# Altium schematic sheet PSOC62_Adapter_V1.2.SchDoc
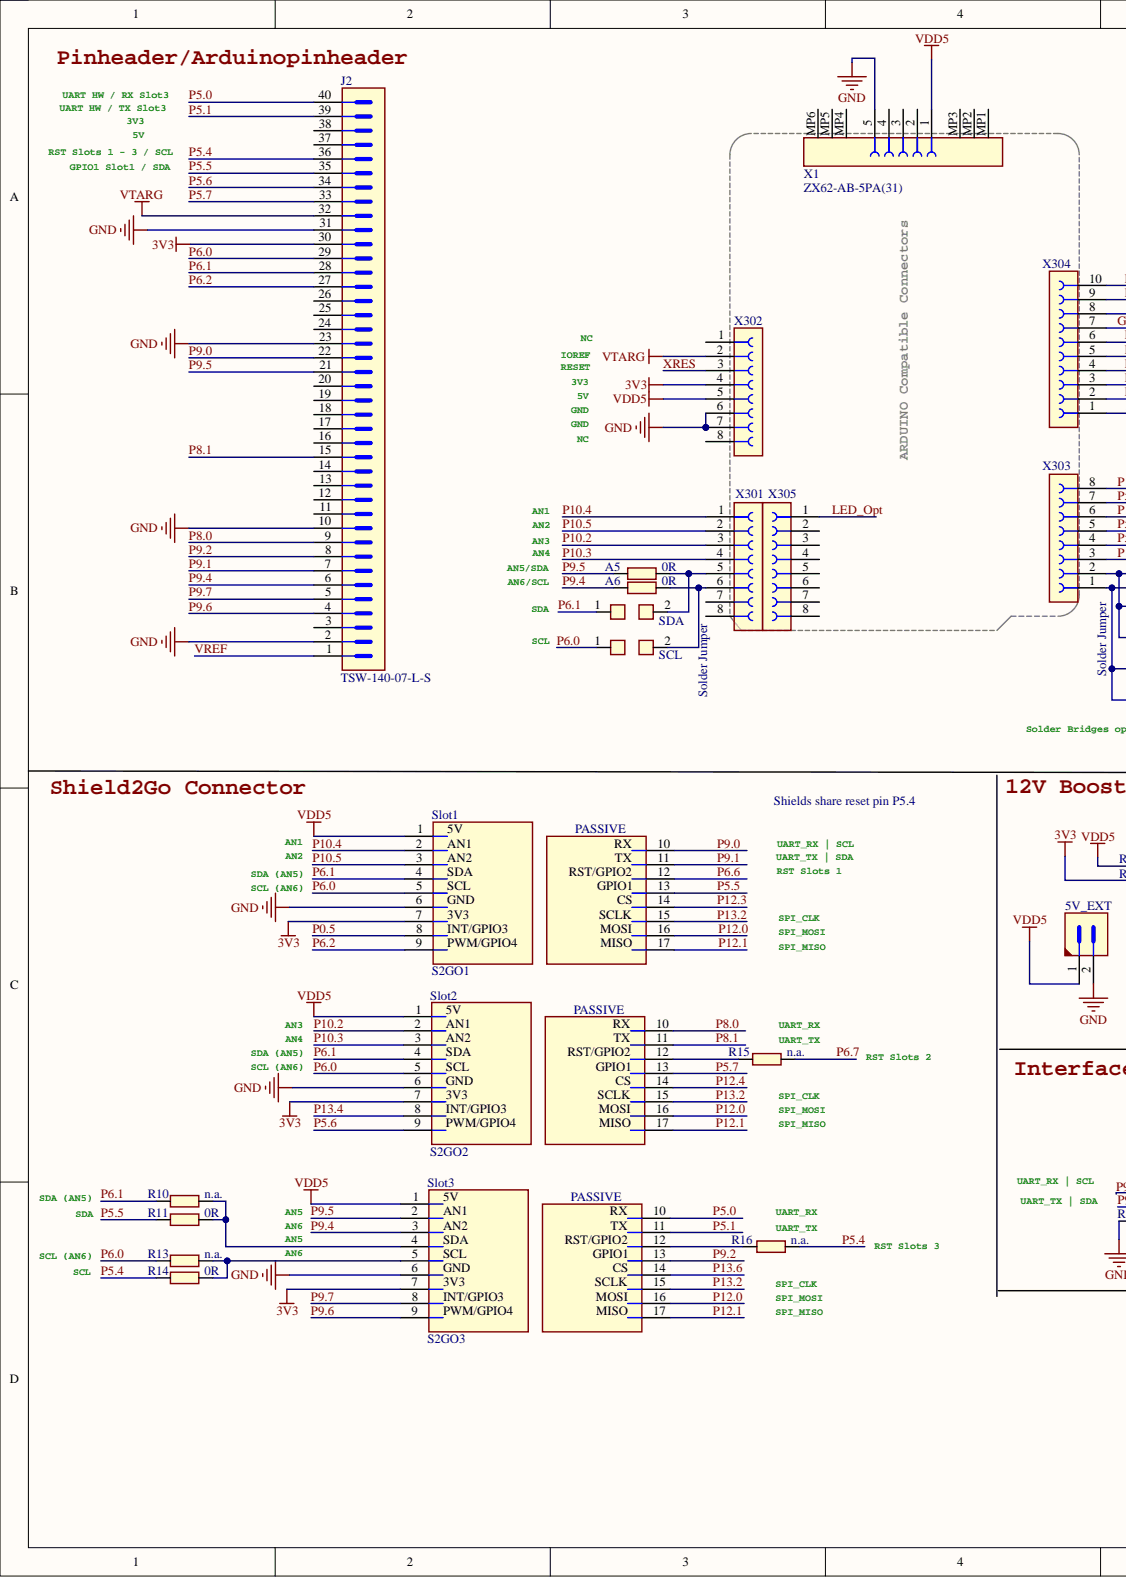
[diagram p0: PSOC62_Adapter_V1.2.SchDoc, left]
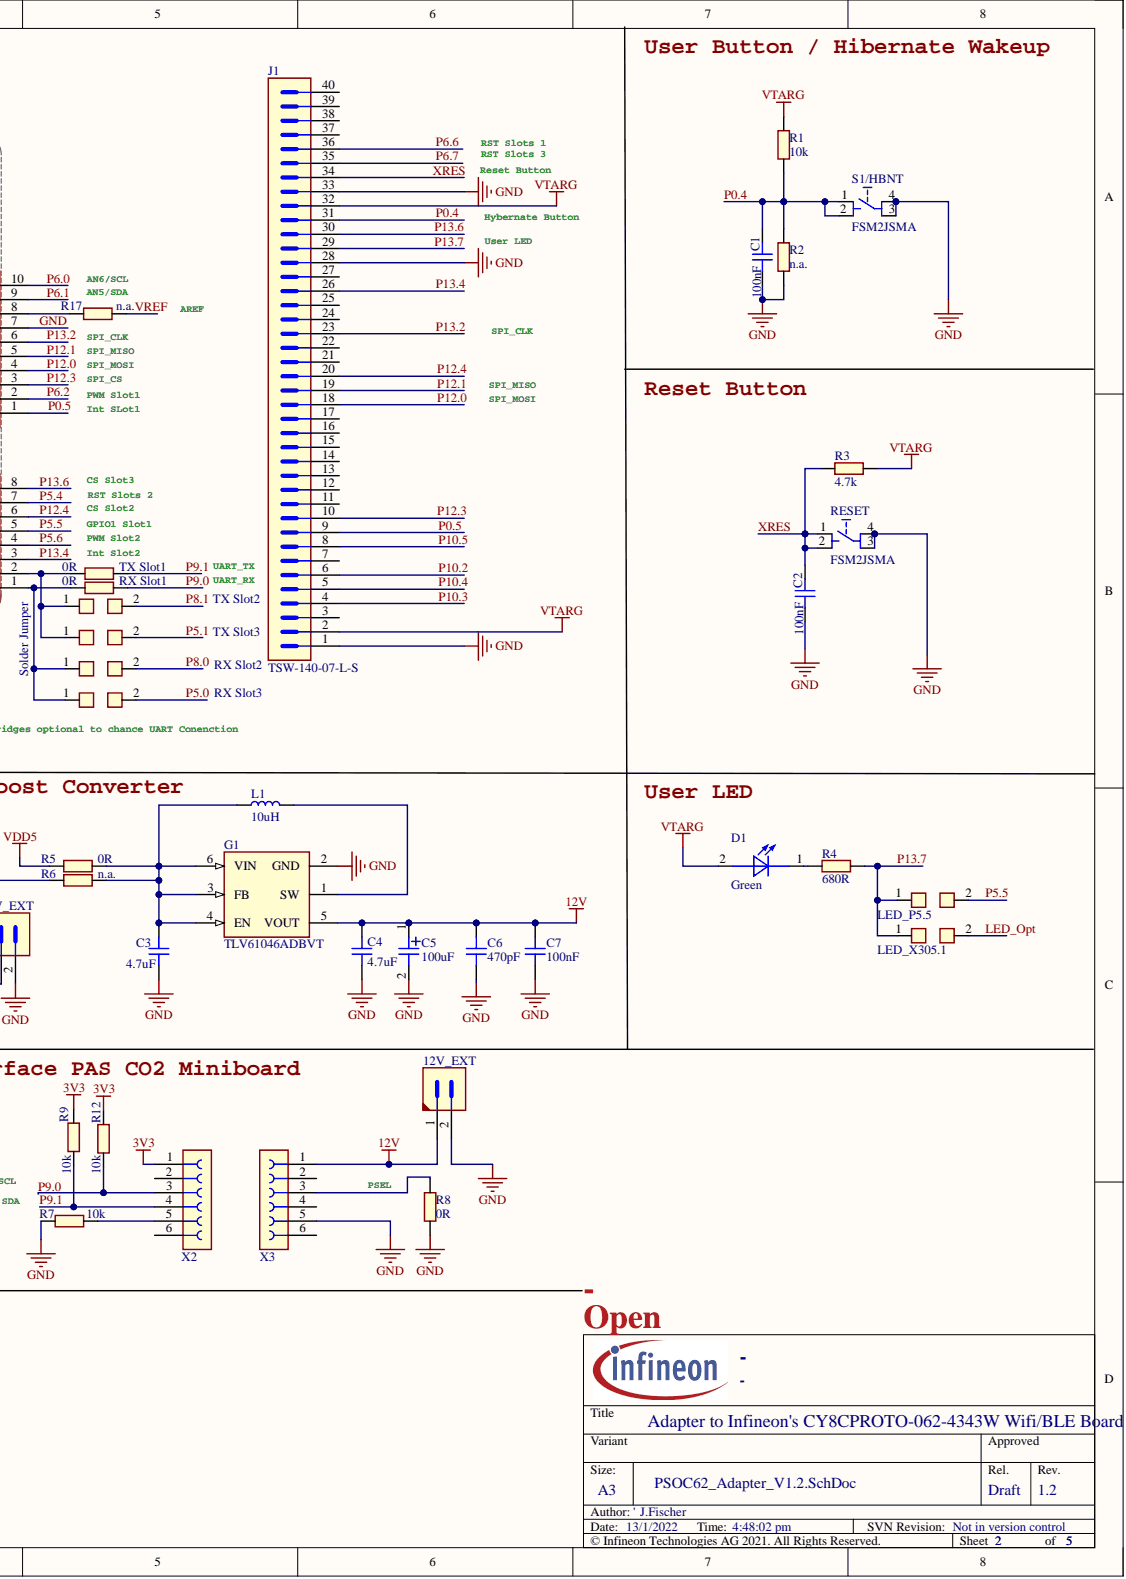
[diagram p1: PSOC62_Adapter_V1.2.SchDoc, right]

## Parts
5V_EXT  TSW-102-07-L-S  [CON-M-THT-TSW-102-07-L-S]  (value not drawn)
12V_EXT  TSW-102-07-L-S  [CON-M-THT-TSW-102-07-L-S]  (value not drawn)
A5  0R  [RESC3225X60N]
A6  0R  [RESC3225X60N]
C1  100nF  [CAPC2013X88N]
C2  100nF  [CAPC2013X88N]
C3  4.7uF  [CAPC1608X90N]
C4  4.7uF  [CAPC1608X90N]
C5  100uF  [CAPAE660X800N-1]
C6  470pF  [CAPC1608X90N]
C7  100nF  [CAPC1608X90N]
D1  Green  [LED-SMD-LG L29K-XXXX-24]
G1  TLV61046ADBVT  [SOT95P280X145-6N-6]
J1  TSW-140-07-L-S  [CON-M-THT-TSW-140-07-L-S]
J2  TSW-140-07-L-S  [CON-M-THT-TSW-140-07-L-S]
L1  10uH  [IND-SMD-VLCF5020]
LED_P5.5  Solder Jumper  [SOLDER_BRIDGE_0.7X1.299PAD]
LED_X305.1  Solder Jumper  [SOLDER_BRIDGE_0.7X1.299PAD]
R1  10k  [RESC3225X60N]
R2  n.a.  [RESC3225X60N]
R3  4.7k  [RESC3225X60N]
R4  680R  [RESC2113X50N]
R5  0R  [RESC3225X60N]
R6  n.a.  [RESC3225X60N]
R7  10k  [RESC3225X60N]
R8  0R  [RESC3225X60N]
R9  10k  [RESC3225X60N]
R10  n.a.  value 0R  Tolerance Neg: 0R  [RESC3225X60N]
R11  0R  [RESC3225X60N]
R12  10k  [RESC3225X60N]
R13  n.a.  value 0R  Tolerance Neg: 0R  [RESC3225X60N]
R14  0R  [RESC3225X60N]
R15  n.a.  [RESC3225X60N]
R16  n.a.  [RESC3225X60N]
R17  n.a.  [RESC2013X60N]
RESET  FSM2JSMA  [FSM2JSMA]
RX_Slot1  0R  [RESC3225X60N]
RX_Slot2  Solder Jumper  [SOLDER_BRIDGE_0.7X1.299PAD]
RX_Slot3  Solder Jumper  [SOLDER_BRIDGE_0.7X1.299PAD]
S1/HBNT  FSM2JSMA  [FSM2JSMA]
SCL  Solder Jumper  [SOLDER_BRIDGE_0.7X1.299PAD]
SDA  Solder Jumper  [SOLDER_BRIDGE_0.7X1.299PAD]
Slot1  S2GO1  [Shield2Go_S2G_1P]  (no BOM)
Slot2  S2GO2  [Shield2Go_S2G_1P]  (no BOM)
Slot3  S2GO3  [Shield2Go_S2G_1P]  (no BOM)
TX_Slot1  0R  [RESC3225X60N]
TX_Slot2  Solder Jumper  [SOLDER_BRIDGE_0.7X1.299PAD]
TX_Slot3  Solder Jumper  [SOLDER_BRIDGE_0.7X1.299PAD]
X1  ZX62-AB-5PA(31)  [CON-USB-SMD-ZX62-AB-5PA(31)]
X2  Socket 6  [CON-F-THT-929850-01-06-RA]  (value not drawn)
X3  Socket 6  [CON-F-THT-929850-01-06-RA]  (value not drawn)
X301  Socket 8  [CON-F-THT-PPTC081LFBN-RC]  (value not drawn)
X302  Socket 8  [CON-F-THT-PPTC081LFBN-RC]  (value not drawn)
X303  Socket 8  [CON-F-THT-PPTC081LFBN-RC]  (value not drawn)
X304  SSQ-110-03-F-S  [CON-F-THT-SSQ-110-03-F-S]  (value not drawn)
X305  Socket 8  [CON-F-THT-PPTC081LFBN-RC]  (value not drawn)

## Nets
3V3: J2.30 R6.2 R9.1 R12.1 Slot1.7 Slot2.7 Slot3.7 X2.1 X302.4
12V: 12V_EXT.1 C4.2 C5.1 C6.2 C7.2 G1.5(VOUT) X3.1
VDD5: 5V_EXT.1 R5.2 Slot1.1 Slot2.1 Slot3.1 X1.1 X302.5
VTARG: D1.2 J1.2 J1.32 J2.32 R1.2 R3.2 X302.2
GND: 5V_EXT.2 12V_EXT.2 C1.2 C2.2 C3.1 C4.1 C5.2 C6.1 C7.1 G1.2(GND) J1.1 J1.28 J1.33 J2.2 J2.10 J2.23 J2.31 R2.1 R7.2 R8.2 RESET.3 RESET.4 S1/HBNT.3 S1/HBNT.4 Slot1.6 Slot2.6 Slot3.6 X1.5 X3.5 X302.6 X302.7 X304.7
LED_Opt: LED_X305.1.2 X305.1
P0.4: C1.1 J1.31 R1.1 R2.2 S1/HBNT.1 S1/HBNT.2
P0.5: J1.9 Slot1.8 X304.1
P5.0: J2.40 RX_Slot3.2 Slot3.10
P5.1: J2.39 Slot3.11 TX_Slot3.2
P5.4: J2.36 R14.2 R16.1 X303.7
P5.5: J2.35 LED_P5.5.2 R11.2 Slot1.13 X303.5
P5.6: J2.34 Slot2.9 X303.4
P5.7: J2.33 Slot2.13
P6.0: J2.29 R13.2 SCL.1 Slot1.5 Slot2.5 X304.10
P6.1: J2.28 R10.2 SDA.1 Slot1.4 Slot2.4 X304.9
P6.2: J2.27 Slot1.9 X304.2
P6.6: J1.36 Slot1.12
P6.7: J1.35 R15.1
P8.0: J2.9 RX_Slot2.2 Slot2.10
P8.1: J2.15 Slot2.11 TX_Slot2.2
P9.0: J2.22 R12.2 RX_Slot1.1 Slot1.10 X2.3
P9.1: J2.7 R9.2 Slot1.11 TX_Slot1.1 X2.4
P9.2: J2.8 Slot3.13
P9.4: A6.2 J2.6 Slot3.3
P9.5: A5.2 J2.21 Slot3.2
P9.6: J2.4 Slot3.9
P9.7: J2.5 Slot3.8
P10.2: J1.6 Slot2.2 X301.3
P10.3: J1.4 Slot2.3 X301.4
P10.4: J1.5 Slot1.2 X301.1
P10.5: J1.8 Slot1.3 X301.2
P12.0: J1.18 Slot1.16 Slot2.16 Slot3.16 X304.4
P12.1: J1.19 Slot1.17 Slot2.17 Slot3.17 X304.5
P12.3: J1.10 Slot1.14 X304.3
P12.4: J1.20 Slot2.14 X303.6
P13.2: J1.23 Slot1.15 Slot2.15 Slot3.15 X304.6
P13.4: J1.26 Slot2.8 X303.3
P13.6: J1.30 Slot3.14 X303.8
P13.7: J1.29 LED_P5.5.1 LED_X305.1.1 R4.2
VREF: J2.1 R17.2
XRES: C2.1 J1.34 R3.1 RESET.1 RESET.2 X302.3
NetA5_1: A5.1 SDA.2 X301.5
NetA6_1: A6.1 SCL.2 X301.6
NetC3_2: C3.2 G1.3(FB) G1.4(EN) G1.6(VIN) L1.1 R5.1 R6.1
NetD1_1: D1.1 R4.1
NetG1_1: G1.1(SW) L1.2
NetR7_1: R7.1 X2.5
NetR8_1: R8.1 X3.3
NetR10_1: R10.1 R11.1 Slot3.4
NetR13_1: R13.1 R14.1 Slot3.5
NetR15_2: R15.2 Slot2.12
NetR16_2: R16.2 Slot3.12
NetR17_1: R17.1 X304.8
NetRX Slot1_2: RX_Slot1.2 RX_Slot2.1 RX_Slot3.1 X303.1
NetTX Slot1_2: TX_Slot1.2 TX_Slot2.1 TX_Slot3.1 X303.2

Not connected: J1 3 7 11 12 13 14 15 16 17 21 22 24 25 27 37 38 39 40; J2 3 11 12 13 14 16 17 18 19 20 24 25 26 37 38; X1 2 3 4 MP1 MP2 MP3 MP4 MP5 MP6; X2 2 6; X3 2 4 6; X301 7 8; X302 1 8; X305 2 3 4 5 6 7 8

## Library data of the parts
5V_EXT 12V_EXT = 382904: Through hole .025 SQ Post Header, 2.54mm pitch, 2 pin, vertical, single row; Legacy PartNumber: CON01500574; Manufacturer: Samtec; Alternat View: Yes; Automotive Qualified: No; Combined Parameters: Board-to-Board Header (male);2x1;Mounting:THT;Orient:0Â° (vertical);Shielded:No; Database Table Name: tParts_all#csv; Legacy DeviceID: SAM_TSW-102-07-L-S; PLMStatus: Active; QuickPick: 0Â° (vertical) / Board-to-Board; Style: Strip; Version Number: V01; Voltage DC (max): na; Article Number: 382904; Component Kind: Standard; ComponentLink1Description: Vendor WebSite; ComponentLink1URL: http://www.samtec.com/; ComponentLink2Description: Datasheet; ComponentLink2URL: http://webnetprod.muc.infineon.com/PCBPortal_Prod/WorkFlows/AltiumComponent/StandardDocumentation?Versionid=382905; Library Name: PCBLibraryData.SVNDblib; Manufacturer Order Number: TSW-102-07-L-S; Package Description: Header,vertical,2.54mm pitch;0.64mm lead width,2 pins,1 row,2 pins per row,5.08mm L X 2.54mm W X 8.636mm H body; Part Type: Connector; ROHS Compliant: Y; Second Source allowed: Yes; Supplier 1: Farnell; Supplier 2: DigiKey; Supplier 3: Mouser; Supplier Part Number 1: 2667448; Supplier Part Number 2: SAM1031-02-ND; Temperature max: 125; Temperature min: -55; Temperature Range: Military; Type: Board-to-Board; Value: TSW-102-07-L-S
A5 A6 R5 R10 R11 R13 R14 RX_Slot1 TX_Slot1 = 355897: Standard Thick Film Chip Resistor; Manufacturer: Vishay; Manufacturer Order Number: CRCW12100000Z0; Multiple Parts: No; Alternate View: Yes; Automotive Qualified: AEC Q200; Combined Parameters: TCR:-;Tol.:-0R/+0R;Vdc:200V;Idc:5A;P:-; Database Table Name: tParts_all#csv; Legacy DeviceID: RES01803200; PLMStatus: Active; QuickPick: 0R / 0R / 1210; Style: Single; Symbol Type: Resistor; Single; Standard; 2 Pins; Version Number: V01; Voltage DC (max): 200V; Article Number: 355897; Component Kind: Standard; ComponentLink1Description: Vendor WebSite; ComponentLink1URL: http://www.vishay.com; ComponentLink2Description: Datasheet; ComponentLink2URL: http://webnetprod.muc.infineon.com/PCBPortal_Prod/WorkFlows/AltiumComponent/StandardDocumentation?Versionid=355898; Legacy PartNumber: RES01803200; Library Name: PCBLibraryData.SVNDblib; PackageReference: 1210; Part Type: Resistor; ROHS Compliant: Y; Second Source allowed: Yes; Supplier 1: Farnell; Supplier 2: DigiKey; Supplier 3: Mouser; Technology: Thick Film; Temperature max: 155; Temperature min: -55; Temperature Range: Military; Tolerance Pos: 0R; Type: Standard
  A5 A6 R5 R11 R14 RX_Slot1 TX_Slot1: Tolerance Neg: 0R; Value: 0R
C1 C2 = 251082: Surface Mount Multilayer Ceramic Chip Capacitor; Manufacturer: Kemet; Manufacturer Order Number: C0805C104J5RAC; Multiple Parts: No; Alternate View: Yes; Combined Parameters: TC:X7R (EIA);Tol.:-5%/+5%;Vdc:50V; Database Table Name: tParts_all#csv; Legacy DeviceID: CAP00004118; Package Imperial: 0805; Package Metric: 2012; PLMStatus: Active; QuickPick: 100nF / 50V / 5% / X7R (EIA) / 0805; Style: Single; Symbol Type: Capacitor; Single; Standard; 2 Pins; Tolerance Neg: 5%; Type: Standard; Version Number: V01; Voltage DC (max): 50V; Article Number: 251082; Automotive Qualified: Yes; Component Kind: Standard; ComponentLink1Description: Vendor WebSite; ComponentLink1URL: http://www.kemet.com; ComponentLink2Description: Datasheet; ComponentLink2URL: http://webnetprod.muc.infineon.com/PCBPortal_Prod/WorkFlows/AltiumComponent/StandardDocumentation?Versionid=251083; Legacy PartNumber: CAP00004118; Library Name: PCBLibraryData.SVNDblib; Package Description: Capacitor,non-polarized,Chip;2.00mm L X 1.25mm W X 0.88mm H; PackageReference: 0805; Part Type: Capacitor; ROHS Compliant: Y; Second Source allowed: Yes; Supplier 1: Farnell; Supplier 2: DigiKey; Supplier 3: Mouser; Supplier Part Number 1: 1414663; Supplier Part Number 3: 80-C0805C104J5RAC; Technology: Ceramic; Temperature max: 125; Temperature min: -55; Temperature Range: Military; Tolerance Pos: 5%; Value: 100nF
C3 C4 = 272300: CAP / CERA / 4.7uF / 25V / 10% / X5R (EIA) / -55Â°C to 85Â°C / 0603(1608) / SMD / -; Manufacturer: MuRata; Manufacturer Order Number: GRM188R61E475KE15; Alternate View: Yes; Automotive Qualified: No; Combined Parameters: TC:X5R (EIA);Tol.:-10%/+10%;Vdc:25V; Database Table Name: tParts_all#csv; Legacy DeviceID: CAP01003467; Package Imperial: 0603; Package Metric: 1608; PLMStatus: Active; QuickPick: 4.7uF / 25V / 10% / X5R (EIA) / CAPC1608X90N; Style: Single; Symbol Type: Capacitor; Single; Standard; 2 Pins; Type: Standard; Version Number: V01; Application: Standard; Article Number: 272300; Component Kind: Standard; ComponentLink1Description: Vendor WebSite; ComponentLink1URL: http://www.murata.com/; ComponentLink2Description: Datasheet; ComponentLink2URL: http://webnetprod.muc.infineon.com/PCBPortal_Prod/WorkFlows/AltiumComponent/StandardDocumentation?Versionid=272301; Legacy PartNumber: CAP01003467; Library Name: PCBLibraryData.SVNDblib; Multiple Parts: No; Package Description: Capacitor,non-polarized,Chip;1.60mm L X 0.80mm W X 0.90mm H; PackageReference: CAPC1608X90N; Part Type: Capacitor; ROHS Compliant: Y; Second Source allowed: Yes; Supplier 1: Farnell; Supplier 2: DigiKey; Supplier 3: Mouser; Technology: Ceramic; Temperature max: 85; Temperature min: -55; Temperature Range: Military; Tolerance Neg: 10%; Tolerance Pos: 10%; Value: 4.7uF; Voltage DC (max): 25V
C5 = 309470: CAP / ELCO / 100uF / 50V / 20% / Aluminiumelectrolytic / -55Â°C to 105Â°C / 6.60mm L X 6.60mm W X 8.00mm H / SMD / -; Manufacturer: Panasonic; Manufacturer Order Number: EEEFTH101XAP; Multible Parts: No; Alternate View: Yes; Automotive Qualified: AEC Q200; Combined Parameters: TC:Aluminiumelectrolytic;Tol.:-20%/+20%;Vdc:50V; Database Table Name: tParts_all#csv; Legacy DeviceID: CAP01010767; PLMStatus: Active; QuickPick: 100uF / 50V / 20% / Aluminiumelectrolytic / SMD; Style: Single; Symbol Type: Capacitor; Single; Polarized; 2 Pins; Pin 1 Positive; Type: Polarized; Version Number: V01; Article Number: 309470; Component Kind: Standard; ComponentLink1Description: Vendor WebSite; ComponentLink1URL: http://panasonic.net/sanyo/index.html; ComponentLink2Description: Datasheet; ComponentLink2URL: http://webnetprod.muc.infineon.com/PCBPortal_Prod/WorkFlows/AltiumComponent/StandardDocumentation?Versionid=309471; Legacy PartNumber: CAP01010767; Library Name: PCBLibraryData.SVNDblib; Package Description: Capacitor,Aluminum Electrolytic;6.60mm L X 6.60mm W X 8.00mm H; PackageReference: SMD; Part Type: Capacitor; ROHS Compliant: Y; Second Source allowed: Yes; Supplier 1: Farnell; Supplier 2: DigiKey; Supplier 3: Mouser; Supplier Part Number 1: 2408683; Supplier Part Number 2: PCE5024TR-ND; Supplier Part Number 3: 667-EEE-FTH101XAP; Technology: Electrolytic; Temperature max: 105; Temperature min: -55; Temperature Range: Military; Tolerance Neg: 20%; Tolerance Pos: 20%; Value: 100uF; Voltage DC (max): 50V
C6 = 297691: CAP / CERA / 470pF / 50V / 20% / X8L (EIA) / -55Â°C to 150Â°C / 0603(1608) / SMD / -; Manufacturer: MuRata; Manufacturer Order Number: GCM188L81H471MA03D; Multiple Parts: No; Alternate View: Yes; Automotive Qualified: AEC Q200; Combined Parameters: TC:X8L (EIA);Tol.:-20%/+20%;Vdc:50V; Database Table Name: tParts_all#csv; Package Imperial: 0603; Package Metric: 1608; PLMStatus: Not Recommended For New Designs; QuickPick: 470pF / 50V / 20% / X8L (EIA) / CAPC1608X90N; Style: Single; Symbol Type: Capacitor; Single; Standard; 2 Pins; Type: Standard; Version Number: V01; Application: Standard; Article Number: 297691; Component Kind: Standard; ComponentLink1Description: Vendor WebSite; ComponentLink1URL: http://www.murata.com/; ComponentLink2Description: Datasheet; ComponentLink2URL: http://webnetprod.muc.infineon.com/PCBPortal_Prod/WorkFlows/AltiumComponent/StandardDocumentation?Versionid=297692; Library Name: PCBLibraryData.SVNDblib; Package Description: Capacitor,non-polarized,Chip;1.60mm L X 0.80mm W X 0.90mm H; PackageReference: CAPC1608X90N; Part Type: Capacitor; ROHS Compliant: Y; Second Source allowed: Yes; Supplier 1: Farnell; Supplier 2: DigiKey; Supplier 3: Mouser; Supplier Part Number 3: 81-GCM188L81H471MA3D; Technology: Ceramic; Temperature max: 150; Temperature min: -55; Temperature Range: Military; Tolerance Neg: 20%; Tolerance Pos: 20%; Value: 470pF; Voltage DC (max): 50V
C7 = 297311: CAP / CERA / 100nF / 50V / 10% / X7R (EIA) / -55Â°C to 125Â°C / 0603(1608) / SMD / -; Manufacturer: MuRata; Manufacturer Order Number: GCM188R71H104KA57; Multiple Parts: No; Alternate View: Yes; Automotive Qualified: AEC Q200; Combined Parameters: TC:X7R (EIA);Tol.:-10%/+10%;Vdc:50V; Database Table Name: tParts_all#csv; Legacy DeviceID: CAP01008535; Package Imperial: 0603; Package Metric: 1608; PLMStatus: Not Recommended For New Designs; QuickPick: 100nF / 50V / 10% / X7R (EIA) / CAPC1608X90N; Style: Single; Symbol Type: Capacitor; Single; Standard; 2 Pins; Type: Standard; Version Number: V01; Application: Standard; Article Number: 297311; Component Kind: Standard; ComponentLink1Description: Vendor WebSite; ComponentLink1URL: http://www.murata.com/; ComponentLink2Description: Datasheet; ComponentLink2URL: http://webnetprod.muc.infineon.com/PCBPortal_Prod/WorkFlows/AltiumComponent/StandardDocumentation?Versionid=297312; Legacy PartNumber: CAP01008535; Library Name: PCBLibraryData.SVNDblib; Package Description: Capacitor,non-polarized,Chip;1.60mm L X 0.80mm W X 0.90mm H; PackageReference: CAPC1608X90N; Part Type: Capacitor; ROHS Compliant: Y; Second Source allowed: Yes; Supplier 1: Farnell; Supplier 2: DigiKey; Supplier 3: Mouser; Technology: Ceramic; Temperature max: 125; Temperature min: -55; Temperature Range: Military; Tolerance Neg: 10%; Tolerance Pos: 10%; Value: 100nF; Voltage DC (max): 50V
D1 = 395008: Surface Mount LED, Green, 570nm; Manufacturer: OSRAM Opto Semiconductors; Manufacturer Order Number: LG L29K-G2J1-24; Automotive Qualified: No; Combined Parameters: Vf:2.2V;If:20mA;Ur:12V;Ir:10nA;Pmax:-; Database Table Name: tParts_all#csv; Legacy DeviceID: DIO01300294; PLMStatus: Active; QuickPick: LED / 0603; Second Source allowed: Yes; Style: Single; Symbol Type: Standard LED Symbol, 1 Cathode, 2 Anode; Version Number: V01; Voltage DC (max): na; Application: Standard; Article Number: 395008; Component Kind: Standard; ComponentLink1Description: Vendor WebSite; ComponentLink1URL: http://www.osram-os.com; ComponentLink2Description: Datasheet; ComponentLink2URL: http://webnetprod.muc.infineon.com/PCBPortal_Prod/WorkFlows/AltiumComponent/StandardDocumentation?Versionid=395009; Legacy PartNumber: DIO01300294; Library Name: PCBLibraryData.SVNDblib; Package Description: LED;2 pin,1.3mm W X 0.80mm L X 0.67mm H body; PackageReference: 0603; Part Type: Diode; ROHS Compliant: Y; Supplier 1: Farnell; Supplier 2: DigiKey; Supplier 3: Mouser; Supplier Part Number 2: 475-2709-2-ND; Supplier Part Number 3: 720-LGL29KG2J124Z; Temperature max: 100; Temperature min: -40; Temperature Range: Industrial; Type: LED; Value: Green
G1 = 679832: 28-V Output Voltage Boost Converter with Power Diode and Isolation Switch; Manufacturer: Texas Instruments; Alternate View: No; Combined Parameters: Switched - -;Vo:3.3V to 28V; Database Table Name: tParts_all#csv; Legacy DeviceID: TI_TLV61046ADBVT; PLMStatus: Active; QuickPick: Switched / SOT23-6 (6); Second Source allowed: Yes; Version Number: V00; Voltage DC (max): na; Article Number: 679832; Automotive Qualified: No; Component Kind: Standard; ComponentLink1Description: Vendor WebSite; ComponentLink1URL: http://www.ti.com; ComponentLink2Description: Datasheet; ComponentLink2URL: http://webnetprod.muc.infineon.com/PCBPortal_Prod/WorkFlows/AltiumComponent/StandardDocumentation?Versionid=679833; Library Name: PCBLibraryData.SVNDblib; Manufacturer Order Number: TLV61046ADBVT; Multiple Parts: No; Package Description: SOT,0.95mm pitch;6 pin,1.60mm W X 2.90mm L X 1.45mm H body; PackageReference: SOT23-6 (6); Part Type: Power Supply; ROHS Compliant: Y; Supplier 1: Farnell; Supplier 2: DigiKey; Supplier 3: Mouser; Supplier Part Number 1: 3007283; Supplier Part Number 2: 296-46927-1-ND; Supplier Part Number 3: 595-TLV61046ADBVT; Symbol Type: 28-V Output Voltage Boost Converter with Power Diode and Isolation Switch; Temperature max: 125; Temperature min: -40; Temperature Range: Automotive; Type: Switched; Value: TLV61046ADBVT
J1 J2 = 386380: Through hole .025'' SQ Post Header, 2.54mm pitch, 40 pin, vertical, single row; Legacy PartNumber: CON01500905; Manufacturer: Samtec; Alternate View: No; Combined Parameters: Board-to-Board/Cable Header (male);40x1;Mounting:THT;Orient:0Â° (vertical);Shielded:No; Database Table Name: tParts_all#csv; Legacy DeviceID: SAM_TSW-140-07-L-S; PLMStatus: Active; QuickPick: 0Â° (vertical) / Board-to-Board/Cable; Second Source allowed: Yes; Style: Strip; Symbol Type: Header 40 Pins; Version Number: V01; Voltage DC (max): na; Article Number: 386380; Automotive Qualified: No; Component Kind: Standard; ComponentLink1Description: Vendor WebSite; ComponentLink1URL: http://www.samtec.com/; ComponentLink2Description: Datasheet; ComponentLink2URL: http://webnetprod.muc.infineon.com/PCBPortal_Prod/WorkFlows/AltiumComponent/StandardDocumentation?Versionid=386381; Library Name: PCBLibraryData.SVNDblib; Manufacturer Order Number: TSW-140-07-L-S; Multiple Parts: No; Package Description: Header, Vertical, 2.54mm pitch; 0.64mm lead width, 40 pins, Single row, 101.6mm L X 2.54mm W X 8.712mm H body; Part Type: Connector; ROHS Compliant: Y; Supplier 1: Farnell; Supplier 2: DigiKey; Supplier 3: Mouser; Supplier Part Number 1: 2035645; Supplier Part Number 2: SAM1031-40-ND; Temperature max: 125; Temperature min: -55; Temperature Range: Military; Type: Board-to-Board/Cable; Value: TSW-140-07-L-S
L1 = 374211: 10 uH / Farnell: 1670092; Manufacturer: TDK Corporation; Manufacturer Order Number: VLCF5020T-100MR87; Alternate View: Yes; Automotive Qualified: No; Combined Parameters: Tol.:-20%/+20%;Rdc:748mR;Idc:400mA;Fres:-; Database Table Name: tParts_all#csv; Legacy DeviceID: IND00702844; PLMStatus: Active; QuickPick: 10 uH / Standard / SMD; Style: Single; Symbol Type: Inductor; Single; Standard; 2 Pins; Tolerance Neg: 20%; Type: Standard; Version Number: V01; Voltage DC (max): na; Article Number: 374211; Component Kind: Standard; ComponentLink1Description: Vendor WebSite; ComponentLink1URL: http://www.tdk.com; ComponentLink2Description: Datasheet; ComponentLink2URL: http://webnetprod.muc.infineon.com/PCBPortal_Prod/WorkFlows/AltiumComponent/StandardDocumentation?Versionid=374212; Legacy PartNumber: IND00702844; Library Name: PCBLibraryData.SVNDblib; Multiple Parts: No; Package Description: Inductor, SMD; 2-Leads, 5mm L X 5mm W X 2mm H body; PackageReference: SMD; Part Type: Inductor; ROHS Compliant: Y; Second Source allowed: Yes; Supplier 1: Farnell; Supplier Part Number 1: 1670092; Technology: Wire Wound; Temperature max: 105; Temperature min: -40; Temperature Range: Industrial; Tolerance Pos: 20%; Value: 10uH
LED_P5.5 LED_X305.1 RX_Slot2 RX_Slot3 SCL SDA TX_Slot2 TX_Slot3 = 433601: Solder Jumper 2 Pins; Legacy PartNumber: CON01500959; Manufacturer: Infineon Technologies; Alternate View: Yes; Automotive Qualified: No; Combined Parameters: - None (onboard);2x1;Mounting:SMT;Orient:None (onboard);Shielded:No; Database Table Name: tParts_all#csv; Legacy DeviceID: IFX_Solder Jumper 2 Pins; PLMStatus: Active; QuickPick: None (onboard); Style: Not Applicable; Version Number: V01; Voltage DC (max): na; Article Number: 433601; Component Kind: Standard; ComponentLink1Description: Vendor WebSite; ComponentLink1URL: https://www.infineon.com/; ComponentLink2Description: Datasheet; Library Name: PCBLibraryData.SVNDblib; Manufacturer Order Number: Solder Jumper 2 Pins; Multiple Parts: No; Package Description: Solder Bridge 2 Pins, 0.7X1.299mm Pad, 1.6mm L X 1.2mm W body; Part Type: Connector; ROHS Compliant: N; Second Source allowed: Yes; Supplier 1: Farnell; Supplier 2: DigiKey; Supplier 3: Mouser; Symbol Type: Solder Jumper 2 Pins; Temperature Range: Military; Value: Solder Jumper 2 Pins
R1 R7 R9 R12 = 358187: Standard Thick Film Chip Resistor; Manufacturer: Vishay; Manufacturer Order Number: CRCW121010K0FK; Multiple Parts: No; Alternate View: Yes; Automotive Qualified: AEC Q200; Combined Parameters: TCR:100ppm/K;Tol.:-1%/+1%;Vdc:200V;Idc:-;P:500mW; Database Table Name: tParts_all#csv; Legacy DeviceID: RES01803657; PLMStatus: Active; QuickPick: 10k / 1% / 0.50 / 1210; Style: Single; Symbol Type: Resistor; Single; Standard; 2 Pins; Tolerance Neg: 1%; Version Number: V01; Voltage DC (max): 200V; Article Number: 358187; Component Kind: Standard; ComponentLink1Description: Vendor WebSite; ComponentLink1URL: http://www.vishay.com; ComponentLink2Description: Datasheet; ComponentLink2URL: http://webnetprod.muc.infineon.com/PCBPortal_Prod/WorkFlows/AltiumComponent/StandardDocumentation?Versionid=358188; Legacy PartNumber: RES01803657; Library Name: PCBLibraryData.SVNDblib; PackageReference: 1210; Part Type: Resistor; ROHS Compliant: Y; Second Source allowed: Yes; Supplier 1: Farnell; Supplier 2: DigiKey; Supplier 3: Mouser; Technology: Thick Film; Temperature max: 155; Temperature min: -55; Temperature Range: Military; Tolerance Pos: 1%; Type: Standard; Value: 10k
R2 R8 = 358187: Standard Thick Film Chip Resistor; Manufacturer: Vishay; Manufacturer Order Number: CRCW121010K0FK; Multiple Parts: No; Alternate View: Yes; Automotive Qualified: AEC Q200; Combined Parameters: TCR:100ppm/K;Tol.:-1%/+1%;Vdc:200V;Idc:-;P:500mW; Database Table Name: tParts_all#csv; Legacy DeviceID: RES01803657; PLMStatus: Active; QuickPick: 10k / 1% / 0.50 / 1210; Style: Single; Symbol Type: Resistor; Single; Standard; 2 Pins; Tolerance Neg: 1%; Value: 10k; Version Number: V01; Voltage DC (max): 200V; Article Number: 358187; Component Kind: Standard; ComponentLink1Description: Vendor WebSite; ComponentLink1URL: http://www.vishay.com; ComponentLink2Description: Datasheet; ComponentLink2URL: http://webnetprod.muc.infineon.com/PCBPortal_Prod/WorkFlows/AltiumComponent/StandardDocumentation?Versionid=358188; Legacy PartNumber: RES01803657; Library Name: PCBLibraryData.SVNDblib; PackageReference: 1210; Part Type: Resistor; ROHS Compliant: Y; Second Source allowed: Yes; Supplier 1: Farnell; Supplier 2: DigiKey; Supplier 3: Mouser; Technology: Thick Film; Temperature max: 155; Temperature min: -55; Temperature Range: Military; Tolerance Pos: 1%; Type: Standard
R3 = 357997: Standard Thick Film Chip Resistor; Manufacturer: Vishay; Manufacturer Order Number: CRCW12104K70FK; Multiple Parts: No; Alternate View: Yes; Automotive Qualified: AEC Q200; Combined Parameters: TCR:100ppm/K;Tol.:-1%/+1%;Vdc:200V;Idc:-;P:500mW; Database Table Name: tParts_all#csv; Legacy DeviceID: RES01803619; PLMStatus: Active; QuickPick: 4.7k / 1% / 0.50 / 1210; Style: Single; Symbol Type: Resistor; Single; Standard; 2 Pins; Tolerance Neg: 1%; Version Number: V01; Voltage DC (max): 200V; Article Number: 357997; Component Kind: Standard; ComponentLink1Description: Vendor WebSite; ComponentLink1URL: http://www.vishay.com; ComponentLink2Description: Datasheet; ComponentLink2URL: http://webnetprod.muc.infineon.com/PCBPortal_Prod/WorkFlows/AltiumComponent/StandardDocumentation?Versionid=357998; Legacy PartNumber: RES01803619; Library Name: PCBLibraryData.SVNDblib; PackageReference: 1210; Part Type: Resistor; ROHS Compliant: Y; Second Source allowed: Yes; Supplier 1: Farnell; Supplier 2: DigiKey; Supplier 3: Mouser; Technology: Thick Film; Temperature max: 155; Temperature min: -55; Temperature Range: Military; Tolerance Pos: 1%; Type: Standard; Value: 4.7k
R4 = 349512: Standard Thick Film Chip Resistor; Manufacturer: Vishay; Manufacturer Order Number: CRCW0805680RFK; Multiple Parts: No; Alternate View: Yes; Automotive Qualified: AEC Q200; Combined Parameters: TCR:100ppm/K;Tol.:-1%/+1%;Vdc:150V;Idc:-;P:125mW; Database Table Name: tParts_all#csv; Legacy DeviceID: RES01801924; PLMStatus: Active; QuickPick: 680R / 1% / 0.1250 / 0805; Style: Single; Symbol Type: Resistor; Single; Standard; 2 Pins; Tolerance Neg: 1%; Version Number: V01; Voltage DC (max): 150V; Article Number: 349512; Component Kind: Standard; ComponentLink1Description: Vendor WebSite; ComponentLink1URL: http://www.vishay.com; ComponentLink2Description: Datasheet; ComponentLink2URL: http://webnetprod.muc.infineon.com/PCBPortal_Prod/WorkFlows/AltiumComponent/StandardDocumentation?Versionid=349513; Legacy PartNumber: RES01801924; Library Name: PCBLibraryData.SVNDblib; PackageReference: 0805; Part Type: Resistor; ROHS Compliant: Y; Second Source allowed: Yes; Supplier 1: Farnell; Supplier 2: DigiKey; Supplier 3: Mouser; Technology: Thick Film; Temperature max: 155; Temperature min: -55; Temperature Range: Military; Tolerance Pos: 1%; Type: Standard; Value: 680R
R6 R15 R16 = 355897: Standard Thick Film Chip Resistor; Manufacturer: Vishay; Manufacturer Order Number: CRCW12100000Z0; Multiple Parts: No; Alternate View: Yes; Automotive Qualified: AEC Q200; Combined Parameters: TCR:-;Tol.:-0R/+0R;Vdc:200V;Idc:5A;P:-; Database Table Name: tParts_all#csv; Legacy DeviceID: RES01803200; PLMStatus: Active; QuickPick: 0R / 0R / 1210; Style: Single; Symbol Type: Resistor; Single; Standard; 2 Pins; Tolerance Neg: 0R; Value: 0R; Version Number: V01; Voltage DC (max): 200V; Article Number: 355897; Component Kind: Standard; ComponentLink1Description: Vendor WebSite; ComponentLink1URL: http://www.vishay.com; ComponentLink2Description: Datasheet; ComponentLink2URL: http://webnetprod.muc.infineon.com/PCBPortal_Prod/WorkFlows/AltiumComponent/StandardDocumentation?Versionid=355898; Legacy PartNumber: RES01803200; Library Name: PCBLibraryData.SVNDblib; PackageReference: 1210; Part Type: Resistor; ROHS Compliant: Y; Second Source allowed: Yes; Supplier 1: Farnell; Supplier 2: DigiKey; Supplier 3: Mouser; Technology: Thick Film; Temperature max: 155; Temperature min: -55; Temperature Range: Military; Tolerance Pos: 0R; Type: Standard
R17 = 328131: RES / STD / 0R / 125mW / 0R / 0ppm/K / -55Â°C to 155Â°C / 0805 / SMD / -; Manufacturer: Yageo; Manufacturer Order Number: RC0805JR-070RL; Alternate View: Yes; Automotive Qualified: No; Combined Parameters: TCR:0ppm/K;Tol.:-0R/+0R;Vdc:150V;Idc:2A;P:125mW; Database Table Name: tParts_all#csv; Legacy DeviceID: RES00000150; PLMStatus: Active; QuickPick: 0R / 0R / 0.125 / 0805; Style: Single; Symbol Type: Resistor; Single; Standard; 2 Pins; Version Number: V01; Voltage DC (max): 150V; Article Number: 328131; Component Kind: Standard; ComponentLink1Description: Vendor WebSite; ComponentLink1URL: http://www.yageo.com/; ComponentLink2Description: Datasheet; ComponentLink2URL: http://webnetprod.muc.infineon.com/PCBPortal_Prod/WorkFlows/AltiumComponent/StandardDocumentation?Versionid=328132; Legacy PartNumber: RES00000150; Library Name: PCBLibraryData.SVNDblib; Multiple Parts: No; PackageReference: 0805; Part Type: Resistor; ROHS Compliant: Y; Second Source allowed: Yes; Supplier 1: Farnell; Supplier 2: DigiKey; Supplier 3: Mouser; Supplier Part Number 1: 9233750RL; Supplier Part Number 2: 311-0.0ARTR-ND; Supplier Part Number 3: 603-RC0805JR-070RL; Technology: Thick Film; Temperature max: 155; Temperature min: -55; Temperature Range: Military; Tolerance Neg: 0R; Tolerance Pos: 0R; Type: Standard; Value: 0R
RESET S1/HBNT = 376475: Micro Miniature Pushbutton Switch; Legacy PartNumber: SW0000003; Manufacturer: Tyco Electronics; Automotive Qualified: No; Database Table Name: tParts_all#csv; Legacy DeviceID: TEC_FSM2JSMA; PLMStatus: Active; Second Source allowed: Yes; Style: Not Applicable; Version Number: V01; Voltage DC (max): na; Article Number: 376475; Component Kind: Standard; ComponentLink1Description: Vendor WebSite; ComponentLink1URL: http://www.tycoelectronics.com/; ComponentLink2Description: Datasheet; ComponentLink2URL: http://webnetprod.muc.infineon.com/PCBPortal_Prod/WorkFlows/AltiumComponent/StandardDocumentation?Versionid=376476; Library Name: PCBLibraryData.SVNDblib; Manufacturer Order Number: FSM2JSMA; Package Description: Switch,Push button ,4.5mm Pitch,Vertical, 6mm L X 6mm W X 5.4mm H body; Part Type: Electro Mechanic; ROHS Compliant: Y; Supplier 1: Farnell; Supplier 2: DigiKey; Supplier 3: Mouser; Supplier Part Number 1: 3801287; Supplier Part Number 3: 506-FSM2JSMA; Temperature max: 85; Temperature min: -35; Temperature Range: Military; Value: FSM2JSMA
Slot1-Slot3 = Shield2Go-S2G-1P: 17 Pin Socket for Shield2Go Boards; Manufacturer: Infineon Technologies; Manufacturer Component Status: Active; AutomotiveQualified: no; ComponentName: S2G-1P; ComponentStatus: Released; ComponentHeight: 0mm; ComponentLink1Description: Vendor WebSite; ComponentLink1URL: https://www.infineon.com/makers; ComponentLink2Description: Datasheet; Library Name: Shield2GoV1.1.SchLib
X1 = 386780: Micro-USB 2.0 Standard, Type AB, Bottom Mount, Shell SMT; Legacy PartNumber: CON01500945; Manufacturer: Hirose Connectors; Automotive Qualified: No; Combined Parameters: Board-to-Cable Socket (female);-x-;Mounting:SMT;Orient:90Â° (right angle);Shielded:Yes; Database Table Name: tParts_all#csv; Legacy DeviceID: HRS_ZX62-AB-5PA(31); PLMStatus: Active; QuickPick: 90Â° (right angle) / Board-to-Cable; Second Source allowed: Yes; Style: USB; Version Number: V01; Voltage DC (max): na; Application: Standard Interface; Article Number: 386780; Component Kind: Standard; ComponentLink1Description: Vendor WebSite; ComponentLink1URL: http://www.hirose.com/; ComponentLink2Description: Datasheet; ComponentLink2URL: http://webnetprod.muc.infineon.com/PCBPortal_Prod/WorkFlows/AltiumComponent/StandardDocumentation?Versionid=386781; Library Name: PCBLibraryData.SVNDblib; Manufacturer Order Number: ZX62-AB-5PA(31); Package Description: SMT, Micro USB Type AB, Right Angle, 5 pins, 8.8mm L X 5.6mm W X 2.8mm H body; Part Type: Connector; ROHS Compliant: Y; Supplier 1: Farnell; Supplier 2: DigiKey; Supplier 3: Mouser; Supplier Part Number 1: 2663341; Supplier Part Number 2: H125279TR-ND; Supplier Part Number 3: 798-ZX62-AB-5PA31; Temperature max: 85; Temperature min: -30; Temperature Range: Military; Type: Board-to-Cable; Value: ZX62-AB-5PA(31)
X2 X3 = 659837: Boardmount Socket, 2.54 mm Pitch, 6 pins, Vertical; Manufacturer: 3M; Manufacturer Order Number: 929850-01-06-RA; Automotive Qualified: No; Combined Parameters: Board-to-Board Socket (female);6x1;Mounting:THT;Orient:0Â° (vertical);Shielded:No; Database Table Name: tParts_all#csv; Legacy DeviceID: 3M_929850-01-06-RA; PLMStatus: Active; QuickPick: 0Â° (vertical) / Board-to-Board; Second Source allowed: Yes; Style: Strip; Value: 929850-01-06-RA; Version Number: V00; Voltage DC (max): na; Article Number: 659837; Component Kind: Standard; ComponentLink1Description: Vendor WebSite; ComponentLink1URL: http://solutions.3m.com/; ComponentLink2Description: Datasheet; ComponentLink2URL: http://webnetprod.muc.infineon.com/PCBPortal_Prod/WorkFlows/AltiumComponent/StandardDocumentation?Versionid=659838; Library Name: PCBLibraryData.SVNDblib; Package Description: Connector, THT, 2.54mm Pitch, 6pins, 15.24mm L X 2.54mm W X 8.387mm H; PackageReference: 6pin, 1row, right angle, female; Part Type: Connector; ROHS Compliant: Y; Supplier 1: Farnell; Supplier 2: DigiKey; Supplier 3: Mouser; Supplier Part Number 2: 929850E-01-06-ND; Supplier Part Number 3: 517-929850-01-06-RA; Temperature max: 105; Temperature min: -40; Temperature Range: Industrial; Type: Board-to-Board
X301-X303 X305 = 382156: .100â€ (2.54 mm) Contact Centers, Female Headers, Straight; Legacy PartNumber: CON01500504; Manufacturer: Sullins; Manufacturer Order Number: PPTC081LFBN-RC; Automotive Qualified: No; Combined Parameters: Board-to-Board Socket (female);8x1;Mounting:THT;Orient:0Â° (vertical);Shielded:No; Database Table Name: tParts_all#csv; Legacy DeviceID: SUL_PPTC081LFBN-RC; PLMStatus: Active; QuickPick: 0Â° (vertical) / Board-to-Board; Second Source allowed: Yes; Style: Strip; Value: PPTC081LFBN-RC; Version Number: V01; Voltage DC (max): na; Article Number: 382156; Component Kind: Standard; ComponentLink1Description: Vendor WebSite; ComponentLink1URL: www.sullinscorp.com; ComponentLink2Description: Datasheet; ComponentLink2URL: http://webnetprod.muc.infineon.com/PCBPortal_Prod/WorkFlows/AltiumComponent/StandardDocumentation?Versionid=382157; Library Name: PCBLibraryData.SVNDblib; Package Description: Socket, THT, 2.54mm pitch, 8pin, Vertical, Single Row, 20.82mm L X 2.54mm W X 8.65mm H body; Part Type: Connector; ROHS Compliant: Y; Supplier 1: Farnell; Supplier 2: DigiKey; Supplier 3: Mouser; Supplier Part Number 2: S7006-ND; Temperature max: 105; Temperature min: -40; Temperature Range: Industrial; Type: Board-to-Board
X304 = 381812: Through-Hole 0.025'' SQ Post Socket, Vertical, 2.54mm Pitch, 10 Pins, Single Row; Legacy PartNumber: CON01500473; Manufacturer: Samtec; Automotive Qualified: No; Combined Parameters: Board-to-Board Socket (female);10x1;Mounting:THT;Orient:0Â° (vertical);Shielded:No; Database Table Name: tParts_all#csv; Legacy DeviceID: SAM_SSQ-110-03-F-S; PLMStatus: Active; QuickPick: 0Â° (vertical) / Board-to-Board; Second Source allowed: Yes; Style: Strip; Version Number: V01; Voltage DC (max): na; Article Number: 381812; Component Kind: Standard; ComponentLink1Description: Vendor WebSite; ComponentLink1URL: http://www.samtec.com/; ComponentLink2Description: Datasheet; ComponentLink2URL: http://webnetprod.muc.infineon.com/PCBPortal_Prod/WorkFlows/AltiumComponent/StandardDocumentation?Versionid=381813; Library Name: PCBLibraryData.SVNDblib; Manufacturer Order Number: SSQ-110-03-F-S; Package Description: Socket,vertical,2.54mm pitch;0.64mm SQ lead,10 pins,1 row, 25.91mm L X 2.41mm W X 8.64mm H body; Part Type: Connector; ROHS Compliant: Y; Supplier 1: Farnell; Supplier 2: DigiKey; Supplier 3: Mouser; Supplier Part Number 1: 2667433; Temperature max: 125; Temperature min: -55; Temperature Range: Military; Type: Board-to-Board; Value: SSQ-110-03-F-S
